# Altium schematic sheet schéma.SchDoc
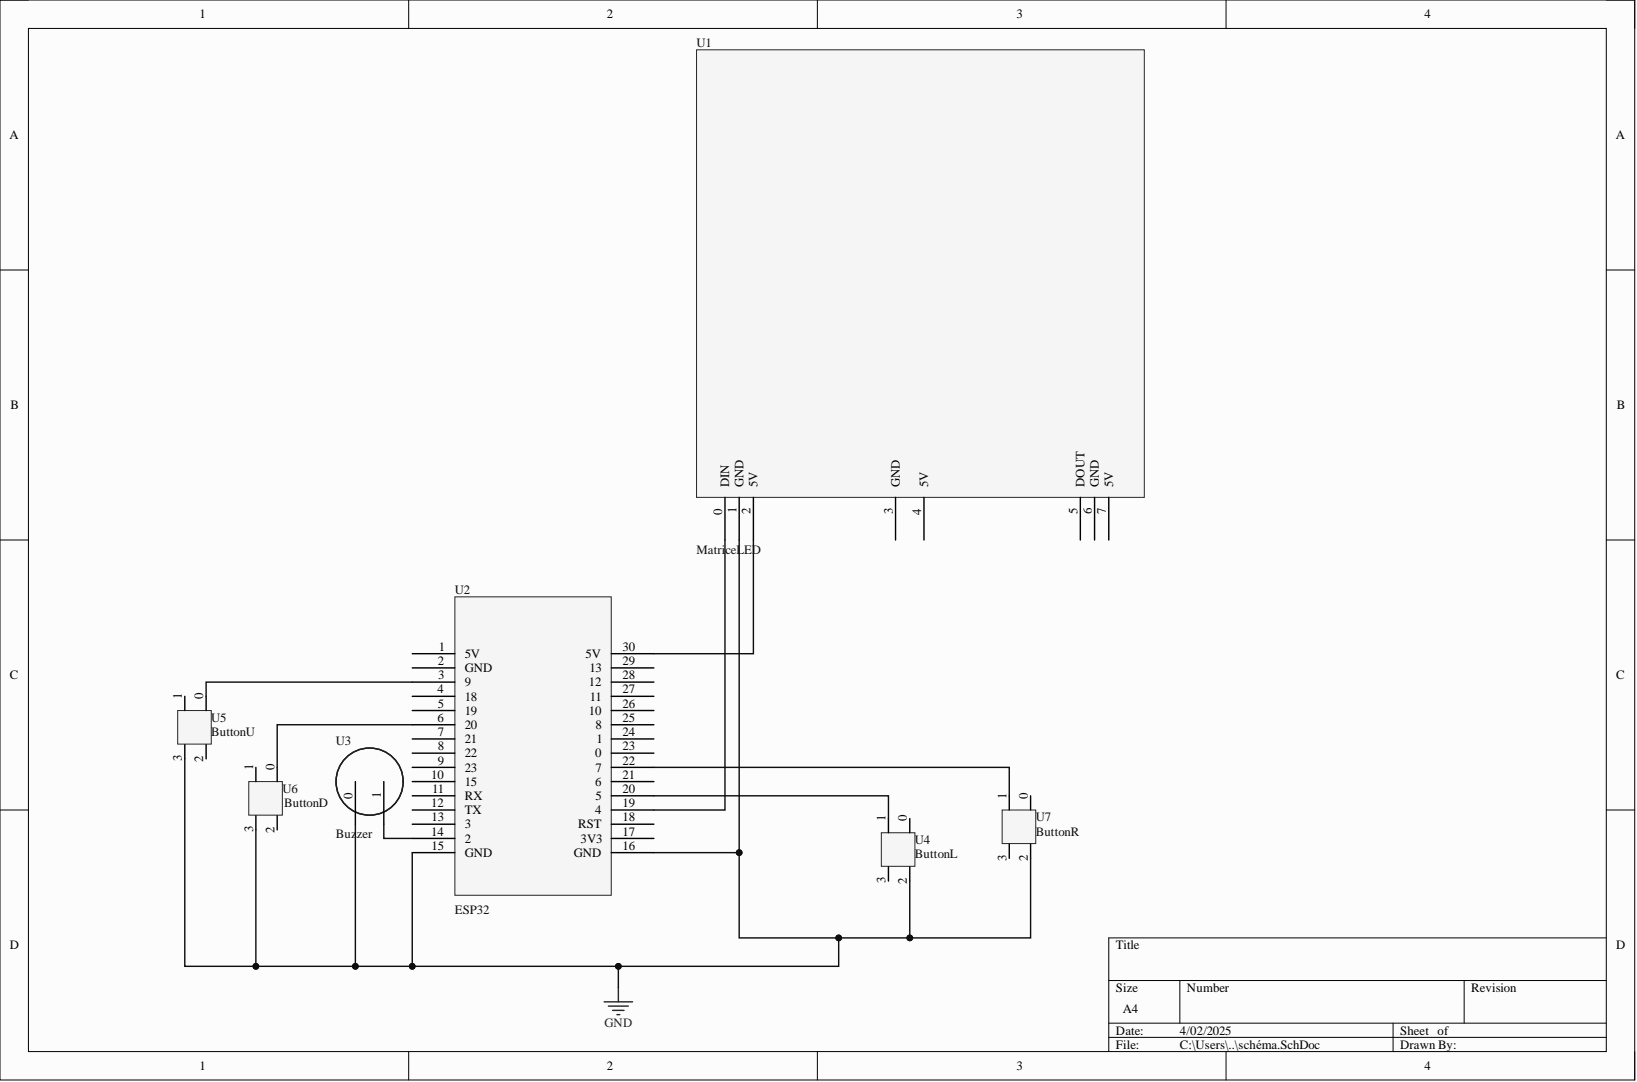
[diagram p0: schéma.SchDoc]

## Parts
U1  MatriceLED  [MATRICEled]
U2  ESP32  [ESP32]
U3  Buzzer  [BUZZER]
U4  ButtonL  [BOUTON]
U5  ButtonU  [BOUTON]
U6  ButtonD  [BOUTON]
U7  ButtonR  [BOUTON]

## Nets
GND: U1.1(GND) U2.15(GND) U2.16(GND) U3.0 U4.2 U5.3 U6.3 U7.2
NetU1_0: U1.0(DIN) U2.19
NetU1_2: U1.2(5V) U2.30(5V)
NetU2_3: U2.3 U5.0
NetU2_6: U2.6 U6.0
NetU2_14: U2.14 U3.1
NetU2_20: U2.20 U4.1
NetU2_22: U2.22 U7.1

Not connected: U1 3(GND) 4(5V) 5(DOUT) 6(GND) 7(5V); U2 1(5V) 2(GND) 4 5 7 8 9 10 11(RX) 12(TX) 13 17(3V3) 18(RST) 21 23 24 25 26 27 28 29; U4 0 3; U5 1 2; U6 1 2; U7 0 3

## Library data of the parts
U2 = ESP32C6: Component Kind: Standard; Library Name: Schlib1.SchLib
U4-U7 = Button: Component Kind: Standard; Library Name: Schlib1.SchLib
U1 = MatriceLED: Component Kind: Standard; Library Name: Schlib1.SchLib
U3 = Buzzer: Component Kind: Standard; Library Name: Schlib1.SchLib
